# Altium schematic sheet Output.SchDoc
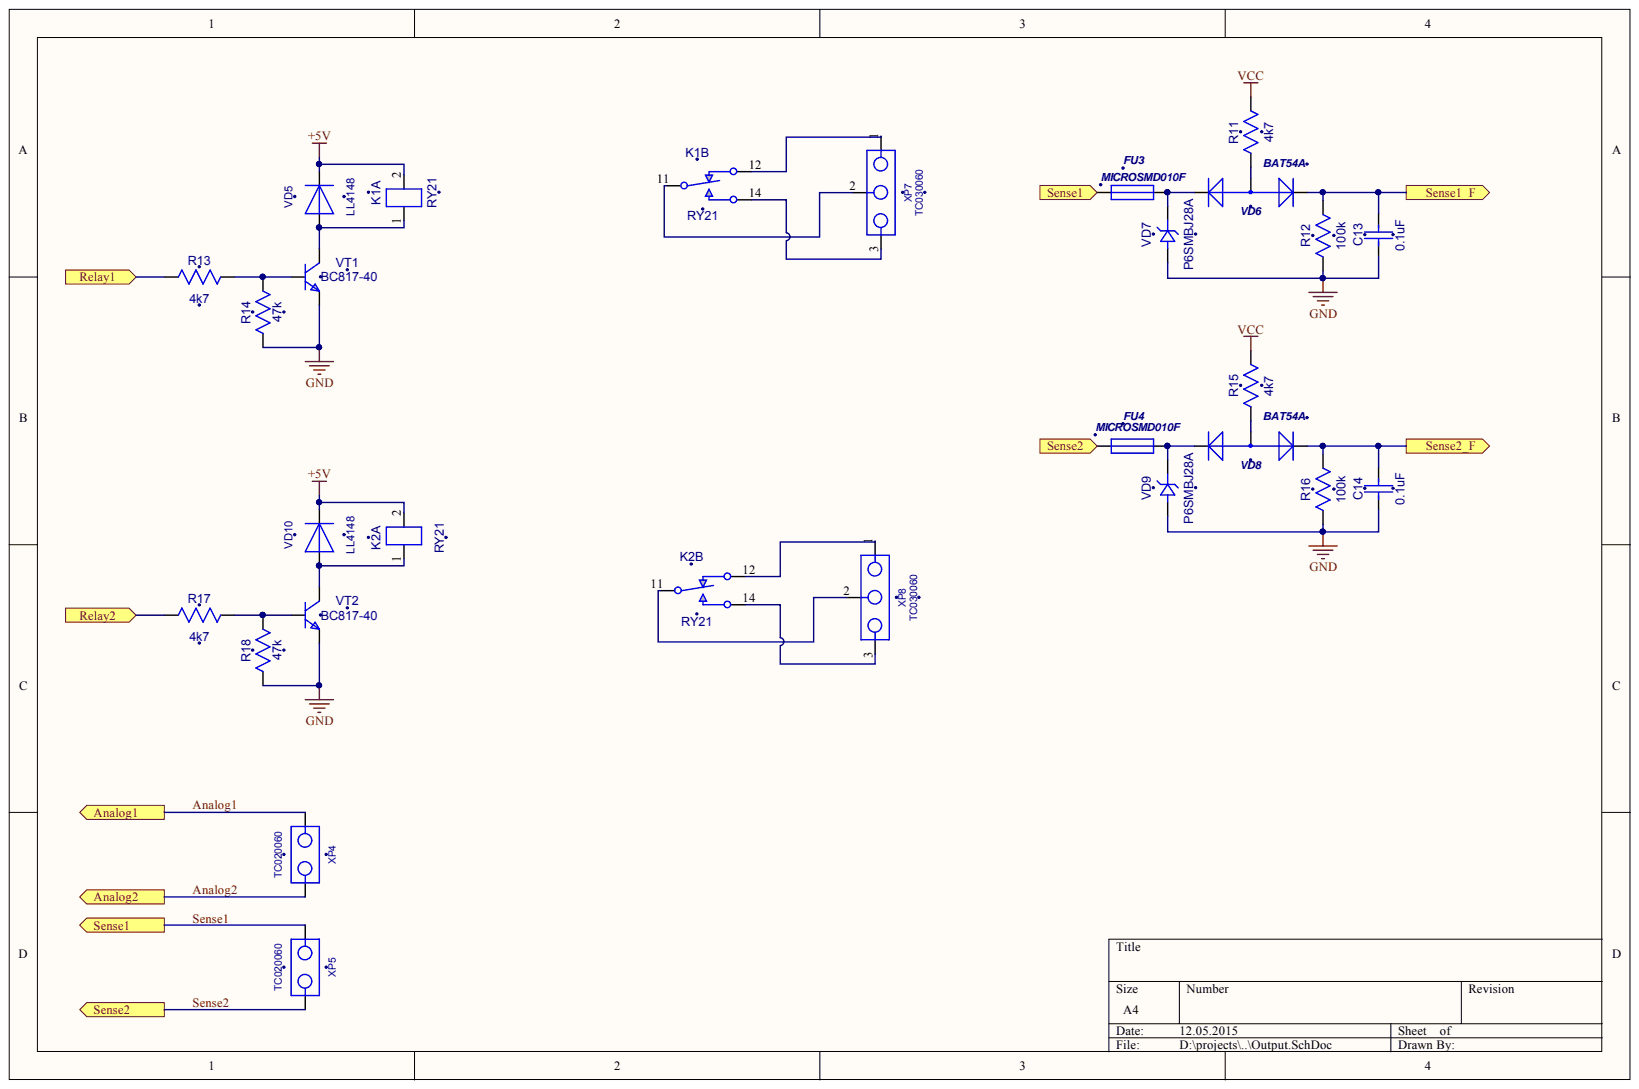
[diagram p0: Output.SchDoc]

## Parts
C13  0.1uF  [CC0603-3M]
C14  0.1uF  [CC0603-3M]
FU3  MICROSMD010F  [RC1210]
FU4  MICROSMD010F  [RC1210]
K1  RY21  [RY21_RY21(Primary)]  (parts A,B)
K2  RY21  [RY21_RY21(Primary)]  (parts A,B)
R11  4k7  [RC0603-3M]
R12  100k  [RC0603-3M]
R13  4k7  [RC0603-3M]
R14  47k  [RC0603-3M]
R15  4k7  [RC0603-3M]
R16  100k  [RC0603-3M]
R17  4k7  [RC0603-3M]
R18  47k  [RC0603-3M]
VD5  LL4148  [SOD-80]
VD6  BAT54A  [SOT-23(123)]
VD7  P6SMBJ28A  [SMB]
VD8  BAT54A  [SOT-23(123)]
VD9  P6SMBJ28A  [SMB]
VD10  LL4148  [SOD-80]
VT1  BC817-40  [SOT-23(123)]
VT2  BC817-40  [SOT-23(123)]
XP4  TC020060  [TC020060]
XP5  TC020060  [TC020060]
XP7  TC030060  [TC030060]
XP8  TC030060  [TC030060]

## Ports
ANALOG1, ANALOG2, RELAY1, RELAY2, SENSE1, SENSE1_F, SENSE2, SENSE2_F

## Nets
+5V: K1.2 K2.2 VD5.2 VD10.2
GND: C13.1 C14.1 R12.1 R14.1 R16.1 R18.1 VD7.1 VD9.1 VT1.2 VT2.2
VCC: R11.2 R15.2
ANALOG1: XP4.1 ; port ANALOG1
ANALOG2: XP4.2 ; port ANALOG2
SENSE1: FU3.1 XP5.1 ; port SENSE1
SENSE2: FU4.1 XP5.2 ; port SENSE2
NetC13_2: C13.2 R12.2 VD6.1 ; port SENSE1_F
NetC14_2: C14.2 R16.2 VD8.1 ; port SENSE2_F
NetFU3_2: FU3.2 VD6.2 VD7.2
NetFU4_2: FU4.2 VD8.2 VD9.2
NetK1_1: K1.1 VD5.1 VT1.3
NetK1_11: K1.11 XP7.2
NetK1_12: K1.12 XP7.1
NetK1_14: K1.14 XP7.3
NetK2_1: K2.1 VD10.1 VT2.3
NetK2_11: K2.11 XP8.2
NetK2_12: K2.12 XP8.1
NetK2_14: K2.14 XP8.3
NetR11_1: R11.1 VD6.3
NetR13_1: R13.1 ; port RELAY1
NetR13_2: R13.2 R14.2 VT1.1
NetR15_1: R15.1 VD8.3
NetR17_1: R17.1 ; port RELAY2
NetR17_2: R17.2 R18.2 VT2.1

## Library data of the parts
C13 C14 = CC0603: Component Kind: Standard; Description: CC0603; Value: 0.1uF; Library Name: gprs_modem.SchLib
FU3 FU4 = MICROSMD: Component Kind: Standard; Description: MICROSMD; Value: MICROSMD010F; Library Name: gprs_modem.SchLib
R11-R18 = RC0603: Component Kind: Standard; Description: RC0603; Library Name: gprs_modem.SchLib
  R11 R13 R15 R17: Value: 4k7
  R12 R16: Value: 100k
  R14 R18: Value: 47k
VD6 VD8 = BAT54A: 1.0 Ampere General Purpose Rectifier; ComponentField6: Published 8-Jun-2000, Modified 11-July-2000: SIM Model Added.; Component Kind: Standard; Simulation: DIODE; Library Name: gprs_modem.SchLib
VD7 VD9 = SMBJ: Component Kind: Standard; Description: SMBJ; Value: P6SMBJ28A; Library Name: gprs_modem.SchLib
VT1 VT2 = BC846BLT1: ComponentField7: Published 29-Sep-2000; Value: {Value}; Component Kind: Standard; Description: BC846BLT1; OriginalName: BC846BLT1; Simulation: BC846BLT1; Library Name: gprs_modem_2.SchLib
K1 K2 = RY21: Component Kind: Standard; Description: RY21; Library Name: shlagbaum.SchLib
VD5 VD10 = LL4148: Component Kind: Standard; Description: LL4148; Library Name: gprs_modem_2.SchLib
XP4 XP5 = TC020060: Component Kind: Standard; Description: TC020060; Library Name: gprs_modem_2.SchLib
XP7 XP8 = TC030060: Component Kind: Standard; Description: TC030060; Library Name: gprs_modem_2.SchLib
